# Altium schematic sheet STM_Power_Supply.SchDoc(STM_Power_Supply)
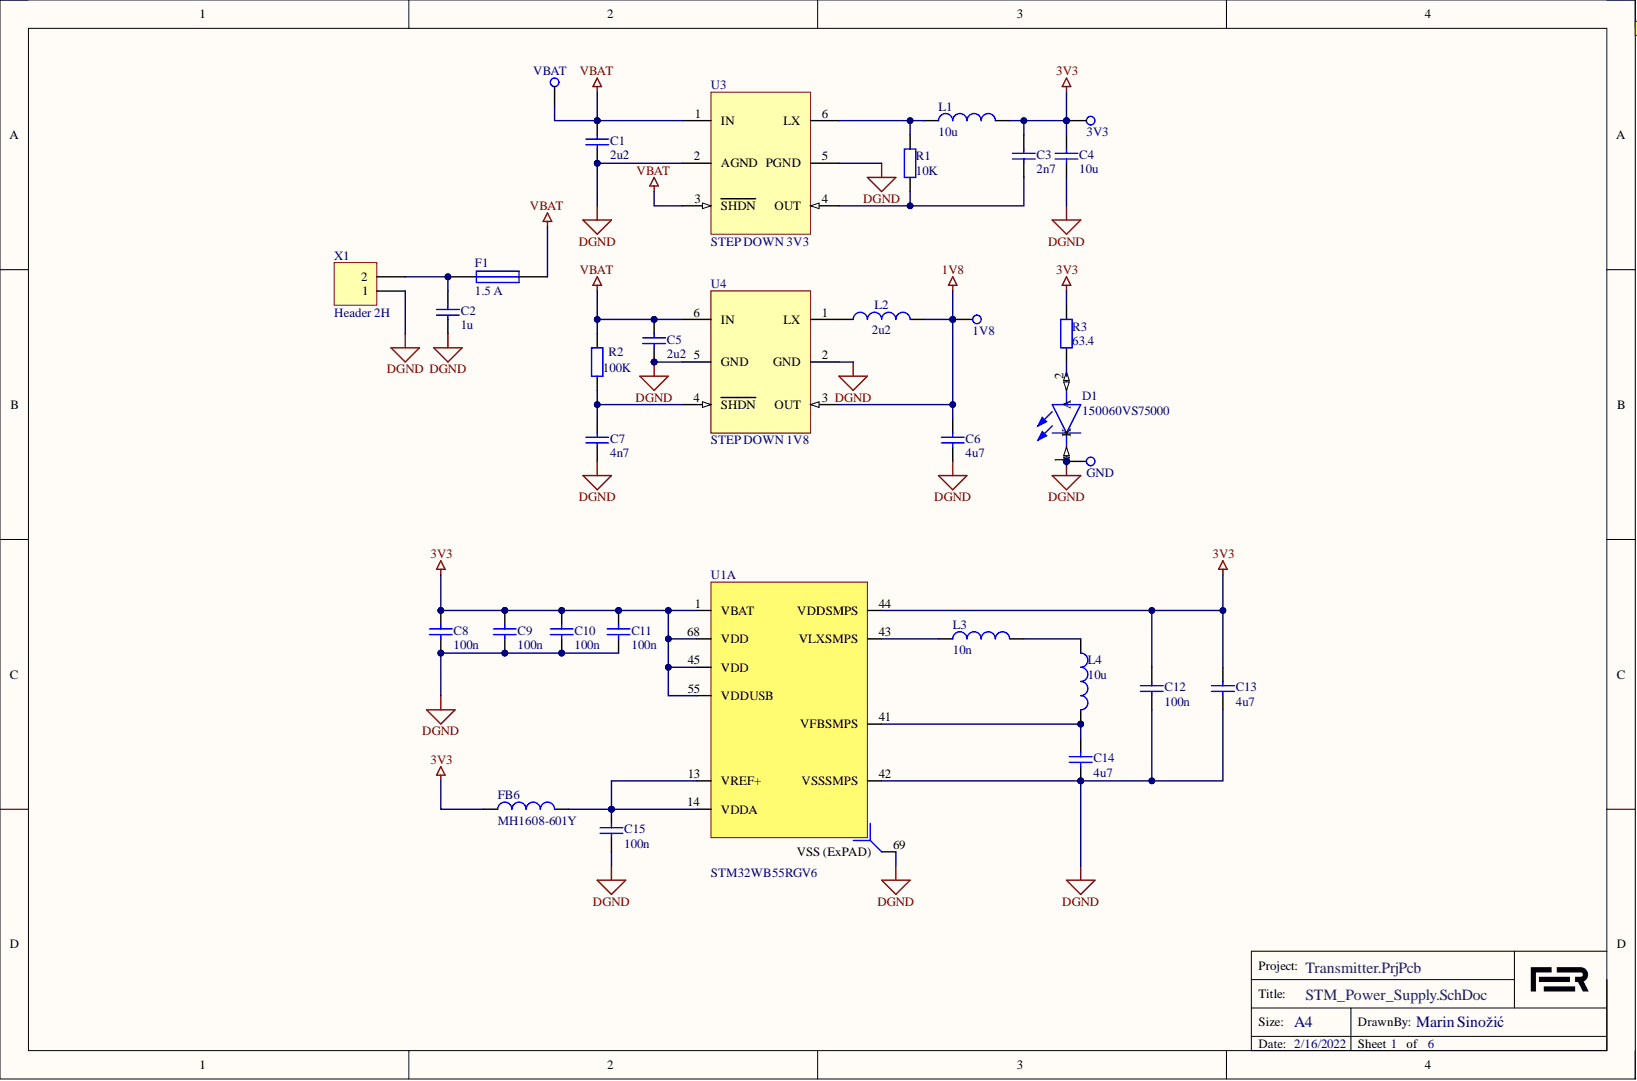
[diagram p0: STM_Power_Supply.SchDoc]

## Parts
1V8  Test point 1mm  [TP]  (value not drawn)  (no BOM)
3V3  3V3  [TP]  (no BOM)
C1  2u2  [0603]
C2  1u  [0805]
C3  2n7  [0603]
C4  10u  [0603]
C5  2u2  [0603]
C6  4u7  [0603]
C7  4n7  [0603]
C8  100n  [0603]
C9  100n  [0603]
C10  100n  [0603]
C11  100n  [0603]
C12  100n  [0603]
C13  4u7  [0603]
C14  4u7  [0603]
C15  100n  [0603]
D1  150060VS75000  [0603]
F1  1.5 A  [MF-USMF075-2]
FB6  MH1608-601Y  [0603]
GND  Test point 1mm  [TP]  (value not drawn)  (no BOM)
L1  10u  [IND_10uH]
L2  2u2  [INDP2929X15N]
L3  10n  [0603]
L4  10u  [0805]
R1  10K  [0603]
R2  100K  [0603]
R3  63.4  [0603]
U1  STM32WB55RGV6  [VFQFPN69]  (part A)
U3  STEP DOWN 3V3  [SOT95P280X145-6N]
U4  STEP DOWN 1V8  [SC70P20X21]
VBAT  VBAT  [TP]  (no BOM)
X1  Header 2H  [HDR1X2]

## Ports
Port

## Nets
1V8: 1V8.1 C6.2 L2.1 U4.3(OUT)
3V3: 3V3.1 C3.2 C4.2 C8.2 C9.2 C10.2 C11.2 C12.2 C13.1 FB6.1 L1.2 R3.2 U1.1(VBAT) U1.44(VDDSMPS) U1.45(VDD) U1.55(VDDUSB) U1.68(VDD)
DGND: C1.1 C2.1 C4.1 C5.1 C6.1 C7.1 C8.1 C9.1 C10.1 C11.1 C12.1 C13.2 C14.1 C15.1 D1.1 GND.1 U1.42(VSSSMPS) U1.69(VSS_(ExPAD)) U3.2(AGND) U3.5(PGND) U4.2(GND) U4.5(GND) X1.1
VBAT: C1.2 C5.2 F1.2 R2.2 U3.1(IN) U3.3(SHDN) U4.6(IN) VBAT.1
NetC2_2: C2.2 F1.1 X1.2
NetC3_1: C3.1 R1.1 U3.4(OUT)
NetC7_2: C7.2 R2.1 U4.4(SHDN)
NetC14_2: C14.2 L4.2 U1.41(VFBSMPS)
NetC15_2: C15.2 FB6.2 U1.13(VREF+) U1.14(VDDA)
NetD1_2: D1.2 R3.1
NetL1_1: L1.1 R1.2 U3.6(LX)
NetL2_2: L2.2 U4.1(LX)
NetL3_1: L3.1 U1.43(VLXSMPS)
NetL3_2: L3.2 L4.1
N00001:

## Library data of the parts
1V8 GND = TP_SMD_c100: Test_Point; Database Table Name: Mechanical; Library Name: RA.DbLib; Part Number: TP_SMD_c100; RA_SN: MECH-0067; Value: Test point 1mm
3V3 VBAT = TP_SMD_c100: Test_Point; Database Table Name: Mechanical; Value: Test point 1mm; Library Name: RA.DbLib; Part Number: TP_SMD_c100; RA_SN: MECH-0067
C1 C5 = Cap: CAP CER 2.2UF 25V X5R 0603; Manufacturer Part Number: 490-10731-1-ND; Component Kind: Standard; LatestRevisionDate: 17-Jul-2002; LatestRevisionNote: Re-released for DXP Platform.; Library Name: Miscellaneous Devices.IntLib; PackageDescription: Radial Cap, Thru-Hole; 2 Leads; 0.3 in Pin Spacing; PackageReference: RAD-0.3; Price: 0.16; Published: 8-Jun-2000; Signal Integrity: Cap; Simulation: CAP; Supplier 1: Digi-Key; Value: 2u2; Publisher: Altium Limited
C2 = Cap: CAP CER 1UF 25V X5R 0805; Manufacturer Part Number: 311-1868-1-ND; Component Kind: Standard; LatestRevisionDate: 17-Jul-2002; LatestRevisionNote: Re-released for DXP Platform.; Library Name: Miscellaneous Devices.IntLib; PackageDescription: Radial Cap, Thru-Hole; 2 Leads; 0.3 in Pin Spacing; PackageReference: RAD-0.3; Price: 0.21; Published: 8-Jun-2000; Signal Integrity: Cap; Simulation: CAP; Supplier 1: Digi-Key; Value: 1u; Publisher: Altium Limited
C3 = Cap: CAP CER 2700PF 50V X7R 0603; Manufacturer Part Number: 1276-2022-1-ND; Component Kind: Standard; LatestRevisionDate: 17-Jul-2002; LatestRevisionNote: Re-released for DXP Platform.; Library Name: Miscellaneous Devices.IntLib; PackageDescription: Radial Cap, Thru-Hole; 2 Leads; 0.3 in Pin Spacing; PackageReference: RAD-0.3; Price: 0.1; Published: 8-Jun-2000; Signal Integrity: Cap; Simulation: CAP; Supplier 1: Digi-Key; Value: 2n7; Publisher: Altium Limited
C4 = Cap: CAP CER 10UF 25V X5R 0603; Manufacturer Part Number: 490-GRM188R61E106KA73JCT-ND; Component Kind: Standard; LatestRevisionDate: 17-Jul-2002; LatestRevisionNote: Re-released for DXP Platform.; Library Name: Miscellaneous Devices.IntLib; PackageDescription: Radial Cap, Thru-Hole; 2 Leads; 0.3 in Pin Spacing; PackageReference: RAD-0.3; Price: 0.32; Published: 8-Jun-2000; Signal Integrity: Cap; Simulation: CAP; Supplier 1: Digi-Key; Value: 10u; Publisher: Altium Limited
C6 C13 C14 = Cap: CAP CER 4.7UF 16V X5R 0603; Manufacturer Part Number: 587-2786-1-ND; Component Kind: Standard; LatestRevisionDate: 17-Jul-2002; LatestRevisionNote: Re-released for DXP Platform.; Library Name: Miscellaneous Devices.IntLib; PackageDescription: Radial Cap, Thru-Hole; 2 Leads; 0.3 in Pin Spacing; PackageReference: RAD-0.3; Price: 0.32; Published: 8-Jun-2000; Signal Integrity: Cap; Simulation: CAP; Supplier 1: Digi-Key; Value: 4u7; Publisher: Altium Limited
C7 = Cap: CAP CER 4700PF 50V X7R 0603; Manufacturer Part Number: 1276-1098-1-ND; Component Kind: Standard; LatestRevisionDate: 17-Jul-2002; LatestRevisionNote: Re-released for DXP Platform.; Library Name: Miscellaneous Devices.IntLib; PackageDescription: Radial Cap, Thru-Hole; 2 Leads; 0.3 in Pin Spacing; PackageReference: RAD-0.3; Price: 0.1; Published: 8-Jun-2000; Signal Integrity: Cap; Simulation: CAP; Supplier 1: Digi-Key; Value: 4n7; Publisher: Altium Limited
C8-C12 C15 = Cap: CAP CER 0.1UF 50V X7R 0603; Manufacturer Part Number: 1276-CL10B104KB8WPNDCT-ND; Component Kind: Standard; LatestRevisionDate: 17-Jul-2002; LatestRevisionNote: Re-released for DXP Platform.; Library Name: Miscellaneous Devices.IntLib; PackageDescription: Radial Cap, Thru-Hole; 2 Leads; 0.3 in Pin Spacing; PackageReference: RAD-0.3; Price: 0.1; Published: 8-Jun-2000; Signal Integrity: Cap; Simulation: CAP; Supplier 1: Digi-Key; Value: 100n; Publisher: Altium Limited
D1 = 150060VS75000: LED GREEN DIFFUSED 0603 SMD; Manufacturer Part Number: 732-12017-1-ND; MF: Wurth Electronics; Availability: Warning; Check_prices: https://www.snapeda.com/parts/150060VS75000/Wurth%20Electronics%20Inc./view-part/588341/?ref=eda; Component Kind: Standard; Library Name: 150060VS75000 (1).IntLib; MP: 150060VS75000; Package: 0603 Wurth Electronics; Price: 0.18; Purchase-URL: https://pricing.snapeda.com/search/part/150060VS75000/?ref=eda; SnapEDA_Link: https://www.snapeda.com/parts/150060VS75000/Wurth%20Electronics%20Inc./view-part/588341/?ref=snap; Supplier 1: Digi-Key
F1 = Fuse 1: PTC RESET FUSE 6V 750MA 1210; Manufacturer Part Number: MF-USMF075-2CT-ND; Component Kind: Standard; LatestRevisionDate: 17-Jul-2002; LatestRevisionNote: Re-released for DXP Platform.; Library Name: Miscellaneous Devices.IntLib; PackageDescription: Thru-Hole; 2 Leads; PackageReference: PIN-W2/E2.8; Price: 0.67; Published: 8-Jun-2000; Simulation: FUSE; Supplier 1: Digi-Key; Publisher: Altium Limited
FB6 = MH1608-601Y: FERRITE BEAD 600 OHM 0603 1LN; Manufacturer: Bourns; Manufacturer Part Number: MH1608-601YCT-ND; Current Rating: 3A; DC Current: 1A; DC Resistance (DCR): 200mR; Impedance: 600R; Number of Lines: 1; Test Frequency: 100MHz; Tolerance: 25%; Case Code (Imperial): 0603; Case Code (Metric): 1608; Case/Package: 0603; Category: Ferrite Beads and Chips; Component Kind: Standard; ComponentLink1Description: https://datasheet.ciiva.com/22632/1727902-22632581.pdf?src-supplier=Element14; ComponentLink1URL: https://datasheet.ciiva.com/22632/1727902-22632581.pdf?src-supplier=Element14; ComponentLink2Description: https://datasheet.ciiva.com/4939/mh-69602-4939639.pdf?src-supplier=Mouser; ComponentLink2URL: https://datasheet.ciiva.com/4939/mh-69602-4939639.pdf?src-supplier=Mouser; ComponentLink3Description: https://datasheet.ciiva.com/15160/getdatasheetpartid-116599-15160410.pdf?src-supplier=Verical; ComponentLink3URL: https://datasheet.ciiva.com/15160/getdatasheetpartid-116599-15160410.pdf?src-supplier=Verical; Core Material: Ferrite; Depth: 1mm; Height: 0.039inch; Length: 0.063inch; Library Name: Tx_board.SchLib; Max Current Rating: 1A; Max DC Current: 1A; Max Operating Temperature: 125°C; Military Standard: Not; Min Operating Temperature: -55°C; Mount: Surface Mount; Package Quantity: 1; Packaging: Tape and Reel; Price: 0.1; Pricing 1: 1=0.10000, 10=0.07300, 25=0.06600, 50=0.05940, 100=0.04360, 250=0.03168, 500=0.02904, 1000=0.02508 (USD); Radiation Hardening: No; REACH SVHC: No SVHC; RoHS: Yes; Stock 1: 35166; Supplier 1: Digi-Key; Supplier Part Number 1: MH1608-601YCT-ND; Termination: SMD/SMT; Width: 0.031inch
L1 = L_0805_10uH: FIXED IND 10UH 550MA 210MOHM SMD; Manufacturer: Murata; Manufacturer Part Number: 308-2298-1-ND; Component Kind: Standard; Library Name: Tx_board.SchLib; Price: 1.23; Pricing 1: 1=0.28000, 10=0.25300, 100=0.17390, 500=0.14864, 1000=0.11385 (USD); RoHS: No; Stock 1: 2742; Supplier 1: Digi-Key; Supplier Part Number 1: 490-18463-1-ND; Value: 10u
L2 = L_0805_10uH: FIXED IND 2.2UH 1.22A 78MOHM SMD; Manufacturer: Murata; Manufacturer Part Number: 490-15950-1-ND; Component Kind: Standard; Library Name: Tx_board.SchLib; Price: 0.36; Pricing 1: 1=0.28000, 10=0.25300, 100=0.17390, 500=0.14864, 1000=0.11385 (USD); RoHS: No; Stock 1: 2742; Supplier 1: Digi-Key; Supplier Part Number 1: 490-18463-1-ND; Value: 2u2
L3 = L_0805_10uH: FIXED IND 10NH 600MA 200MOHM SMD; Manufacturer: Murata; Manufacturer Part Number: 490-15244-1-ND; Component Kind: Standard; Library Name: Tx_board.SchLib; Price: 0.14; Pricing 1: 1=0.28000, 10=0.25300, 100=0.17390, 500=0.14864, 1000=0.11385 (USD); RoHS: No; Stock 1: 2742; Supplier 1: Digi-Key; Supplier Part Number 1: 490-18463-1-ND; Value: 10n
L4 = L_0805_10uH: FIXED IND 10UH 100MA 780MOHM SMD; Manufacturer: Murata; Manufacturer Part Number: 490-4029-1-ND; Component Kind: Standard; Library Name: Tx_board.SchLib; Price: 0.25; Pricing 1: 1=0.28000, 10=0.25300, 100=0.17390, 500=0.14864, 1000=0.11385 (USD); RoHS: No; Stock 1: 2742; Supplier 1: Digi-Key; Supplier Part Number 1: 490-18463-1-ND; Value: 10u
R1 = Res2: RES SMD 10K OHM 0.5% 1/5W 0603; Manufacturer Part Number: P20397CT-ND; Component Kind: Standard; LatestRevisionDate: 17-Jul-2002; LatestRevisionNote: Re-released for DXP Platform.; Library Name: Miscellaneous Devices.IntLib; PackageDescription: Axial Device, Thru-Hole; 2 Leads; 0.4 in Pin Spacing; PackageReference: AXIAL-0.4; Price: 0.16; Published: 8-Jun-2000; Signal Integrity: Res; Simulation: RESISTOR; Supplier 1: Digi-Key; Value: 10K; Publisher: Altium Limited
R2 = Res2: RES 100 KOHMS 0.5% 1/10W 0603; Manufacturer Part Number: P123902CT-ND; Component Kind: Standard; LatestRevisionDate: 17-Jul-2002; LatestRevisionNote: Re-released for DXP Platform.; Library Name: Miscellaneous Devices.IntLib; PackageDescription: Axial Device, Thru-Hole; 2 Leads; 0.4 in Pin Spacing; PackageReference: AXIAL-0.4; Price: 0.11; Published: 8-Jun-2000; Signal Integrity: Res; Simulation: RESISTOR; Supplier 1: Digi-Key; Value: 100K; Publisher: Altium Limited
R3 = Res2: RES SMD 63.4 OHM 0.1% 1/10W 0603; Manufacturer Part Number: YAG4584CT-ND; Component Kind: Standard; LatestRevisionDate: 17-Jul-2002; LatestRevisionNote: Re-released for DXP Platform.; Library Name: Miscellaneous Devices.IntLib; PackageDescription: Axial Device, Thru-Hole; 2 Leads; 0.4 in Pin Spacing; PackageReference: AXIAL-0.4; Price: 0.32; Published: 8-Jun-2000; Signal Integrity: Res; Simulation: RESISTOR; Supplier 1: Digi-Key; Value: 63.4; Publisher: Altium Limited
U1 = STM32WB55RGV6: MCU 32-Bit STM32WB55 ARM Cortex M4 RISC 1MB Flash 1.7V to 3.6V 68-Pin VFQFPN Tray; Manufacturer Part Number: 497-18488-ND; Component Kind: Standard; Library Name: Transmitter.SchLib; Price: 11.67; Supplier 1: Digi-Key
U3 = MAX1921EUT33+T: IC REG BUCK 3.3V 400MA SOT23-6; Manufacturer Part Number: MAX1921EUT33+T-ND; Component Kind: Standard; Library Name: Transmitter.SchLib; Price: 3.34; Supplier 1: Digi-Key
U4 = MAX8640YEXT18+T: IC REG BUCK 1.8V 500MA SC70-6; Manufacturer Part Number: MAX8640YEXT18+TCT-ND; Component Kind: Standard; Library Name: Transmitter.SchLib; Price: 4.69; Supplier 1: Digi-Key
X1 = Header 2H: Header, 2-Pin, Right Angle; Component Kind: Standard; LatestRevisionDate: 17-Jul-2002; LatestRevisionNote: Re-released for DXP Platform.; Library Name: Miscellaneous Connectors.IntLib; Signal Integrity: Connector; Publisher: Altium Limited
